# Altium schematic sheet notch+out.SchDoc(notch+out)
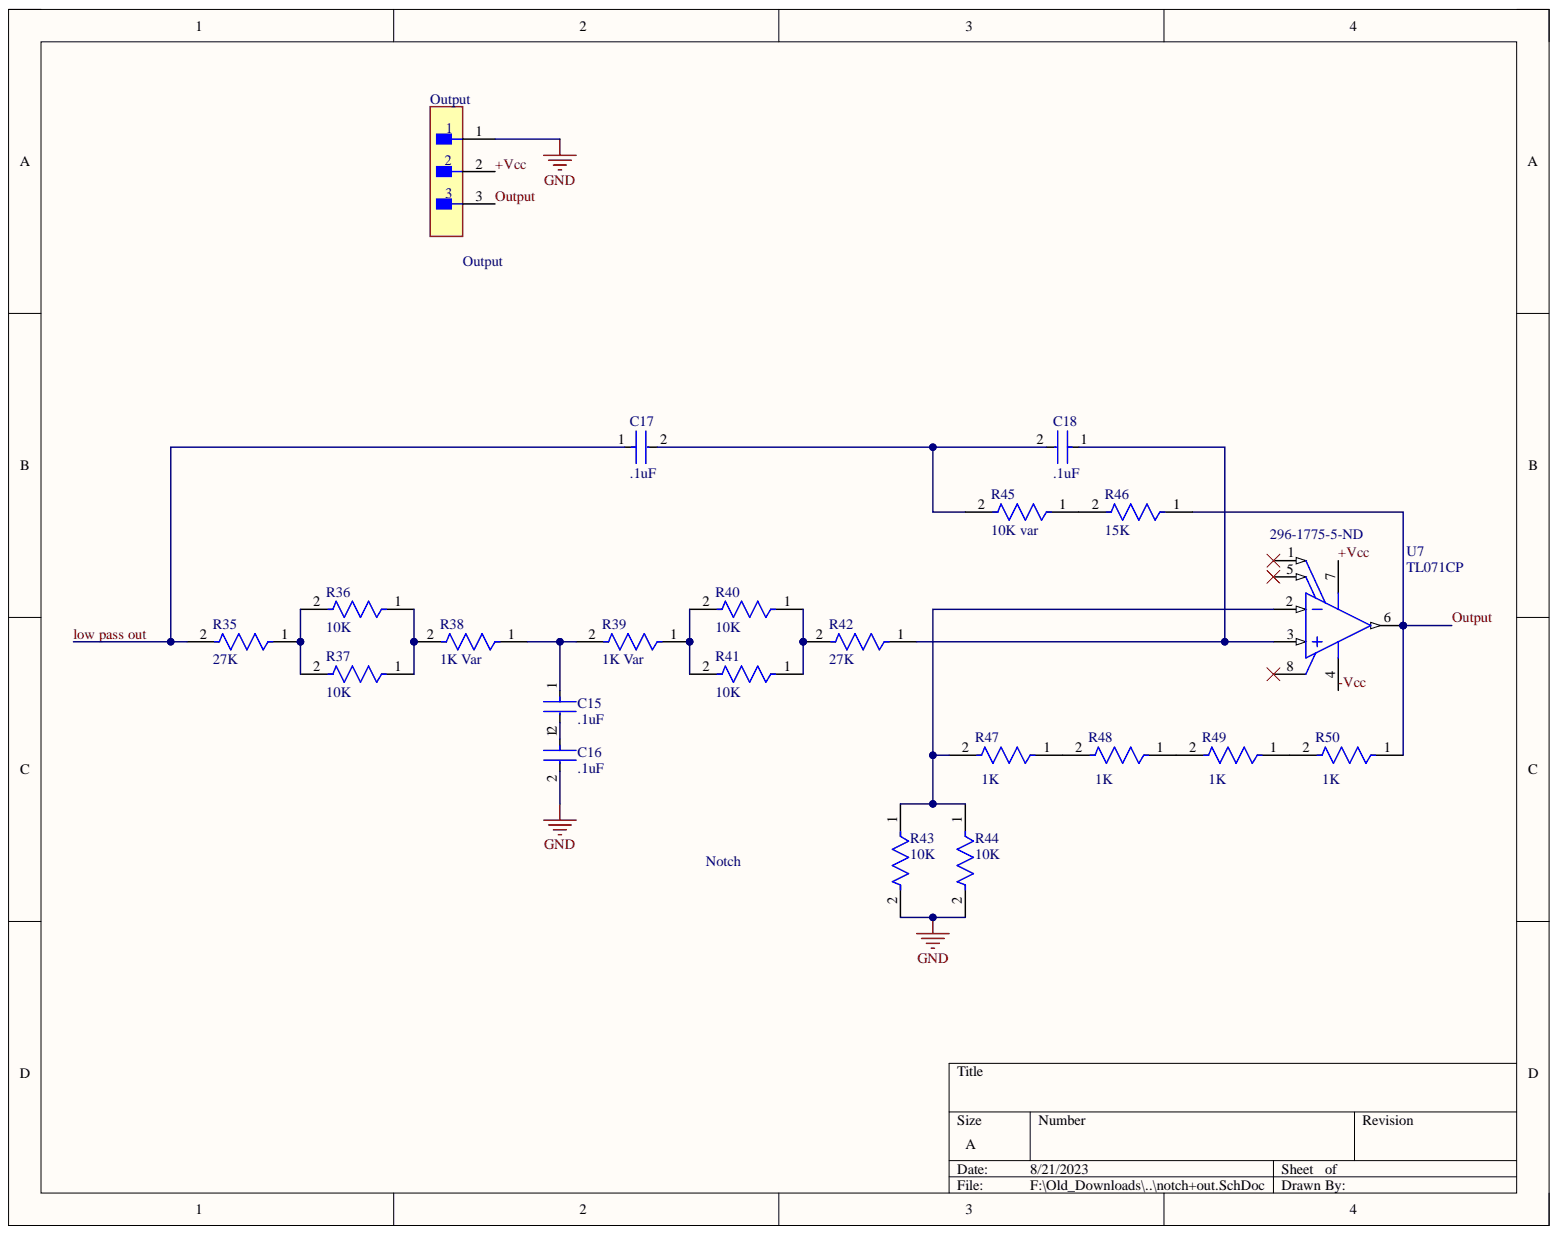
[diagram p0: notch+out.SchDoc]

## Parts
C15  .1uF  [FP-K15H5-MFG]
C16  .1uF  [FP-K15H5-MFG]
C17  .1uF  [FP-K15H5-MFG]
C18  .1uF  [FP-K15H5-MFG]
Output  87224-3  [FP-87224-3-MFG]  (value not drawn)
R35  27K  [FP-CFR-25-MFG]
R36  10K  [FP-CFR-25-MFG]
R37  10K  [FP-CFR-25-MFG]
R38  1K Var  [FP-CFR-25-MFG]
R39  1K Var  [FP-CFR-25-MFG]
R40  10K  [FP-CFR-25-MFG]
R41  10K  [FP-CFR-25-MFG]
R42  27K  [FP-CFR-25-MFG]
R43  10K  [FP-CFR-25-MFG]
R44  10K  [FP-CFR-25-MFG]
R45  10K var  [FP-CFR-25-MFG]
R46  15K  [FP-CFR-25-MFG]
R47  1K  [FP-CFR-25-MFG]
R48  1K  [FP-CFR-25-MFG]
R49  1K  [FP-CFR-25-MFG]
R50  1K  [FP-CFR-25-MFG]
U7  TL071CP  Supplier Part Number 1: 296-1775-5-ND  [P0008A]

## Nets
GND: C16.2 Output.1 R43.2 R44.2
+Vcc: Output.2 U7.7
-Vcc: U7.4
low pass out: C17.1 R35.2
Output: Output.3 R46.1 R50.1 U7.6
NetC15_1: C15.1 R38.1 R39.2
NetC15_2: C15.2 C16.1
NetC17_2: C17.2 C18.2 R45.2
NetC18_1: C18.1 R42.1 U7.3
NetR35_1: R35.1 R36.2 R37.2
NetR36_1: R36.1 R37.1 R38.2
NetR39_1: R39.1 R40.2 R41.2
NetR40_1: R40.1 R41.1 R42.2
NetR43_1: R43.1 R44.1 R47.2 U7.2
NetR45_1: R45.1 R46.2
NetR47_1: R47.1 R48.2
NetR48_1: R48.1 R49.2
NetR49_1: R49.1 R50.2

Not connected: U7 1 5 8

## Library data of the parts
C15-C18 = CMP-02417-000048-1: CAP CER 0.1UF 50V X7R RADIAL; Capacitance: 100nF; Lead Diameter: 0.5mm; Lead Length: 30mm; Lead/Base Style: Formed Leads; Tolerance: 10%; Case/Package: Dipped; Component Kind: Standard; Construction: RADIAL; Depth: 2.5mm; Diameter: 500um; Dielectric: X7R; Height: 4mm; Height - Seated (Max): 0.1inch; Lead Pitch: 5mm; Length: 4mm; Library Name: Altium Content Vault; Max Operating Temperature: 125°C; Min Operating Temperature: -55°C; Mount: Through Hole; Package Quantity: 5000; Packaging: Bulk; Radiation Hardening: No; RoHS Compliant: Yes; Series: K; Termination: Radial; Thickness: 2.6mm; Voltage: 50V; Voltage Rating: 50V; Voltage Rating (DC): 50V; Weight: 0.005644oz; Width: 2.5mm
Output = CMP-2000-05697-2: CONN HEADER VERT 3POS 2.54MM; Approvals: CSA; Board Standoff: No (Without); Connector Type: Plug; Contact Current Rating: 3A; Contact Post Length: 0.125inch; High Speed Serial Data Connector: No; Number of Contacts: 3; PCB Mount Retention: Without; PCB Mounting Orientation: Vertical; PCB Thickness: 1.397mm; Color: Black; Component Kind: Standard; Contact Gender: Male; Contact Material: Bronze; Contact Mating Length: 8.08mm; Contact Plating: Gold; Contact Protection: No (Without); Contact Shape: Square; Contact Type: Male Pin; Current Rating: 3A; Depth: 2.54mm; ELV: Compliant; Flammability Rating: UL94 V-0; Gasket: No (Without); High Temperature Housing: No; Housing Color: Black; Housing Material: Thermoplastic; Keyed: No; Lead Free: Lead Free; Length: 7.21mm; Library Name: Altium Content Vault; Mating Alignment: No (Without); Mating Connector Lock: No (Without); Max Operating Temperature: 105°C; Min Operating Temperature: -65°C; Mount: Through Hole; Number of Positions: 3; Number of Rows: 1; Orientation: Straight; Package Quantity: 520; Packaging: Carton; Pitch: 2.54mm; Radiation Hardening: No; RoHS Compliant: Yes; Row Spacing: 2.54mm; Selectively Loaded: No (Without); Series: AMPMODU MOD II; Stabilizers: No (Without); Strain Relief: No (Without); Termination: Through Hole
R35-R50 = CMP-03413-000009-1: RES 10 OHM 1/4W 5% AXIAL; Max Power Dissipation: 250mW; Resistance: 10R; Temperature Coefficient: 500ppm/°C; Case/Package: Axial; Component Kind: Standard; Length: 0.248inch; Library Name: Altium Content Vault; Max Operating Temperature: 155°C; Min Operating Temperature: -55°C; Number of Terminations: 2; Package Quantity: 200; Packaging: Bulk; Resistor Type: Carbon Film; RoHS Compliant: Yes; Series: Carbon Film; Tolerance: 5%
U7 = CMP-0017-00972-2: Low-Noise JFET-Input General-Purpose Operational Amplifier, 7 to 36 V, 0 to 70 degC, 8-pin DIP (P8), Pb-Free (RoHS); Manufacturer: Texas Instruments; CMRR(Min)(dB): 70; GBW(Typ)(MHz): 3; IIB(Max)(pA): 200; Iq per channel(Max)(mA): 2.5; Number of Channels: 1; Offset Drift(Typ)(uV/C): 18; Rating: Military; Slew Rate(Typ)(V/us): 13; Sub Family: General Purpose Amplifier, High Supply Voltage Amplifier (>=30V); Total Supply Voltage(Max)(+5V is 5, +/-5V is 10): 36; Total Supply Voltage(Min)(+5V is 5, +/-5V is 10): 7; Vio (25C)(Max)(mV): 10; Code_JEDEC: MS-001; Component Kind: Standard; ComponentLink1Description: Manufacturer URL; ComponentLink1URL: http://www.ti.com/; ComponentLink2Description: Datasheet; ComponentLink2URL: http://www.ti.com/lit/ds/symlink/tl071.pdf; ComponentLink3Description: Package Specification; ComponentLink3URL: http://www.ti.com/litv/pdf/mpdi001b; DatasheetVersion: SLOS080J; Eco-Plan: Pb-Free (RoHS); Library Name: Altium Content Vault; Mounting Technology: Through Hole; PackageDescription: 8-Pin Plastic In-Line, Body 9.59 x 6.35 mm, Pitch 2.54 mm; PackageReference: P0008A; PackageVersion: 4040082/E, 04/2010; PartNumber: TL071CP; Supplier 1: Digi-Key; Vn at 1kHz(Typ)(nV/rtHz): 18
